# Altium schematic sheet FEZ-Bit-Misc.SchDoc(FEZ-Bit-Misc)
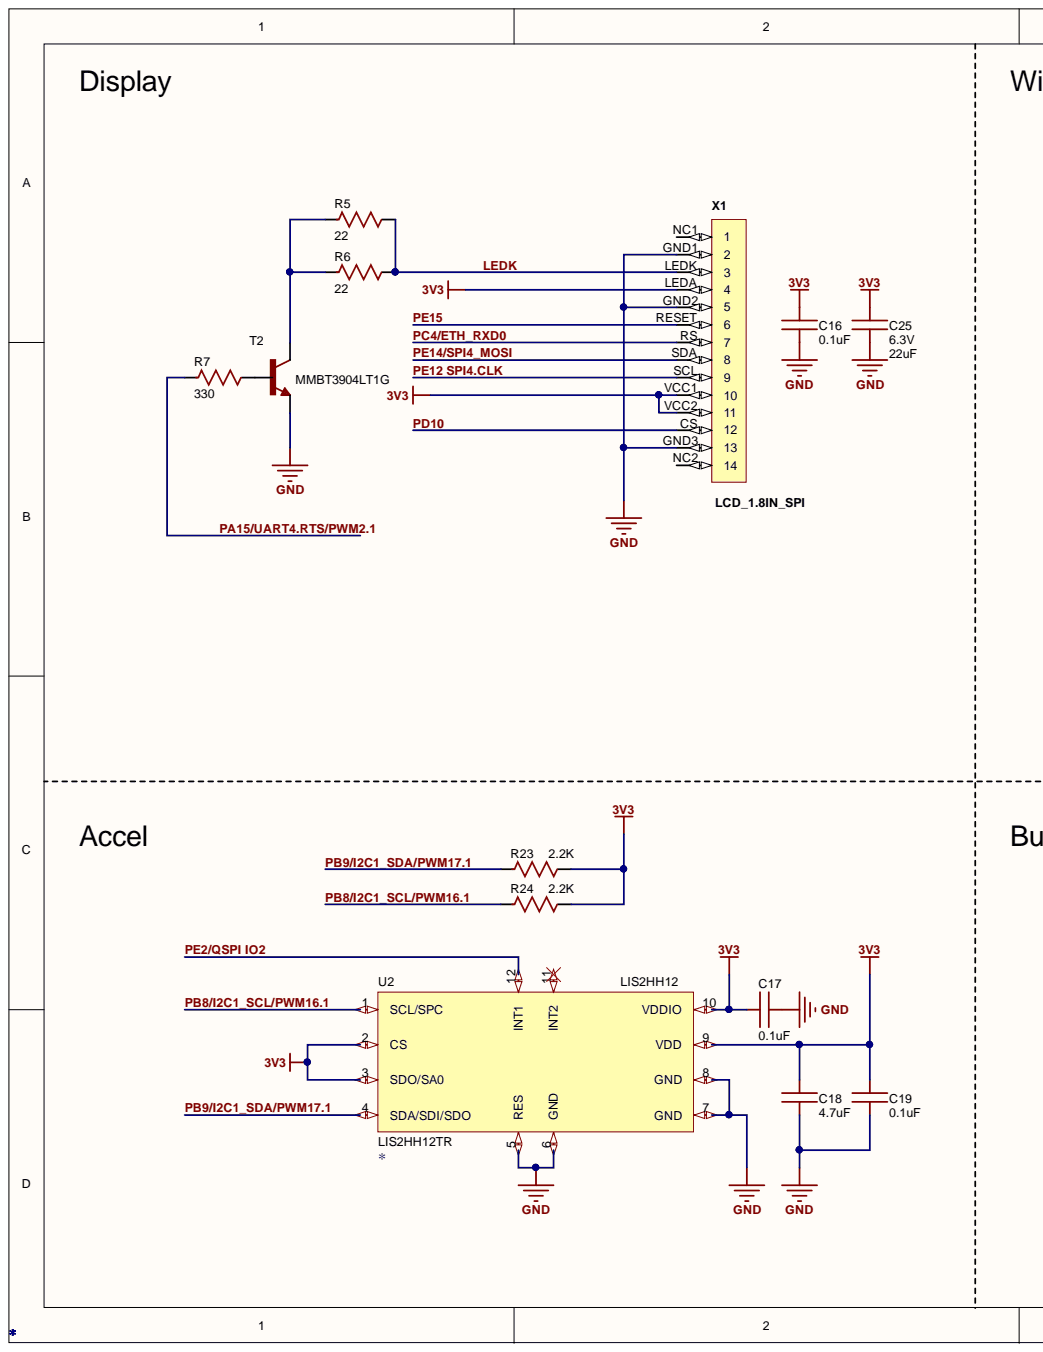
[diagram p0: FEZ-Bit-Misc.SchDoc, left]
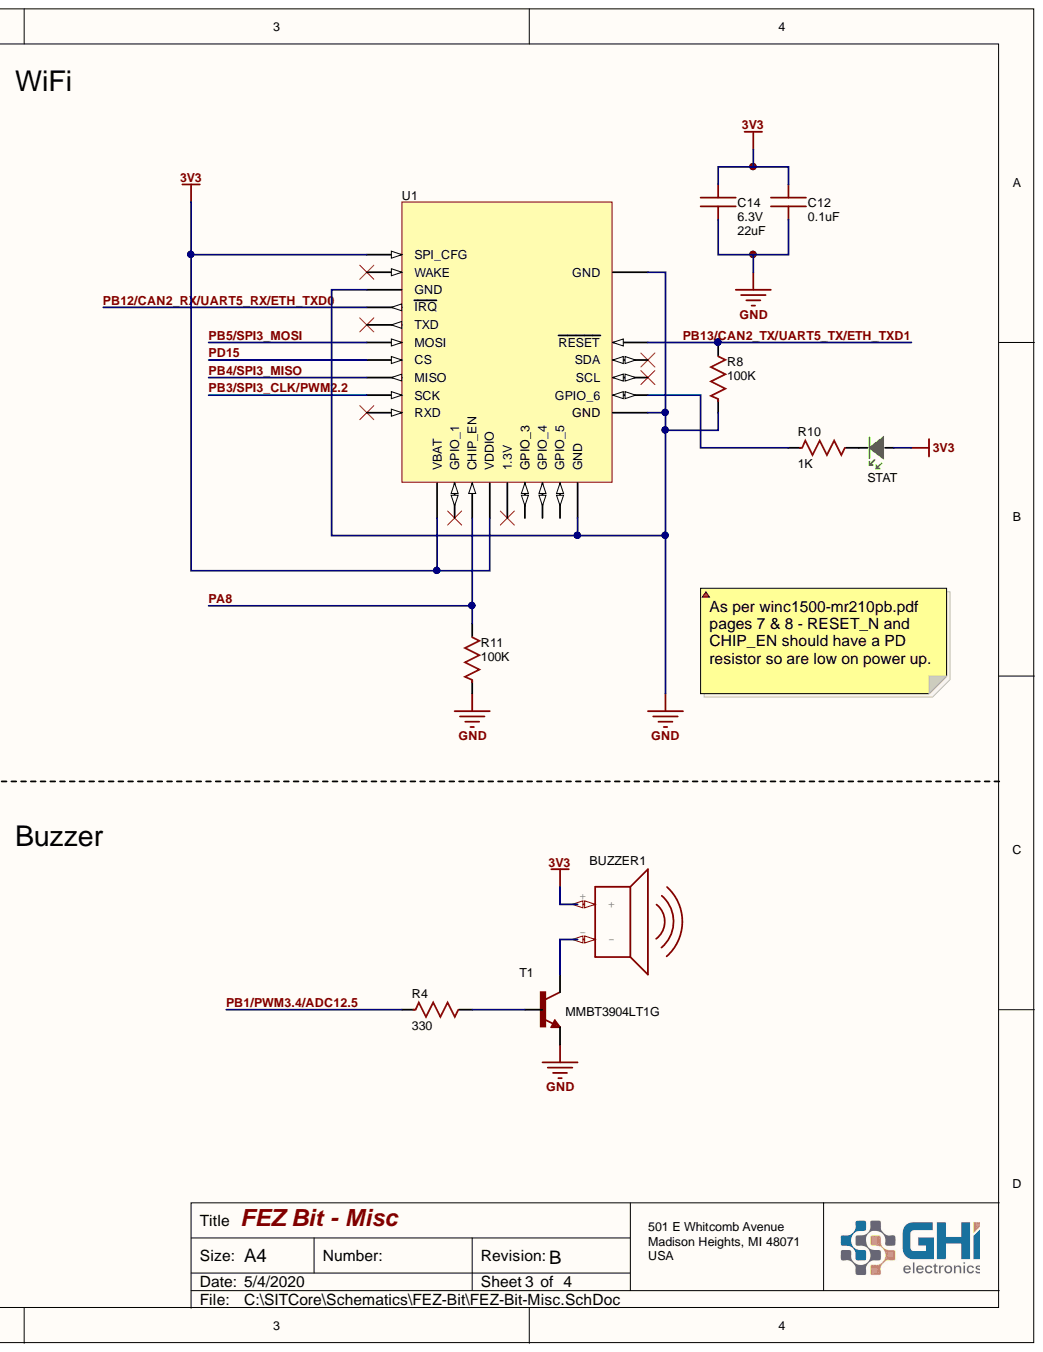
[diagram p1: FEZ-Bit-Misc.SchDoc, right]

## Parts
BUZZER1  Buzzer - Small  [KLJ-SMD-BUZZER]  (value not drawn)
C12  value 0.1uF  [C0603 (1608 Metric)]  (hidden comment: 0603 Cap 0.1uF)
C14  value 22uF  Voltage: 6.3V  [C0805 L]  (hidden comment: 0805 Cap 22uF)
C16  value 0.1uF  [C0603 (1608 Metric)]  (hidden comment: 0603 Cap 0.1uF)
C17  value 0.1uF  [C0603 (1608 Metric)]  (hidden comment: 0603 Cap 0.1uF)
C18  value 4.7uF  [C0603 (1608 Metric)]  (hidden comment: 0603 Cap 4.7uF)
C19  value 0.1uF  [C0603 (1608 Metric)]  (hidden comment: 0603 Cap 0.1uF)
C25  value 22uF  Voltage: 6.3V  [C0805 L]  (hidden comment: 0805 Cap 22uF)
R4  value 330  [R0603 (1608 Metric)]  (hidden comment: 0603 330)
R5  value 22  [R0603 (1608 Metric)]  (hidden comment: 0603 22)
R6  value 22  [R0603 (1608 Metric)]  (hidden comment: 0603 22)
R7  value 330  [R0603 (1608 Metric)]  (hidden comment: 0603 330)
R8  value 100K  [R0603 (1608 Metric)]  (hidden comment: 0603 Res 100K)
R10  value 1K  [R0603 (1608 Metric)]  (hidden comment: 0603 1K)
R11  value 100K  [R0603 (1608 Metric)]  (hidden comment: 0603 Res 100K)
R23  value 2.2K  [R0603 (1608 Metric)]  (hidden comment: 0603 2.2K)
R24  value 2.2K  [R0603 (1608 Metric)]  (hidden comment: 0603 2.2K)
STAT  0603 LED Green  [0603 LED Green]  (value not drawn)
T1  3904  value MMBT3904LT1G  [SOT23]
T2  3904  value MMBT3904LT1G  [SOT23]
U1  ATWINC1500_MR210PB  [ATWINC1500_MR210PA]  (value not drawn)
U2  value LIS2HH12TR  [LGA-12(ST)]
X1  LCD_1.8IN_SPI  [LCD_1.8IN]

## Nets
3V3: BUZZER1.+ C12.2 C14.2 C16.2 C17.2 C18.2 C19.2 C25.2 R23.2 R24.2 STAT.2 U1.J10(SPI_CFG) U1.J20(VBAT) U1.J23(VDDIO) U2.2(CS) U2.3(SDO/SA0) U2.9(VDD) U2.10(VDDIO) X1.LEDA X1.VCC1 X1.VCC2
GND: C12.1 C14.1 C16.1 C17.1 C18.1 C19.1 C25.1 R8.1 R11.1 T1.2 T2.2 U1.J9(GND) U1.J12(GND) U1.J28(GND) U1.J29(GND) U2.5(RES) U2.6(GND) U2.7(GND) U2.8(GND) X1.GND1 X1.GND2 X1.GND3
LEDK: R5.2 R6.2 X1.LEDK
PA8: R11.2 U1.J22(CHIP_EN)
PA15/UART4.RTS/PWM2.1: R7.1
PB1/PWM3.4/ADC12.5: R4.1
PB3/SPI3_CLK/PWM2.2: U1.J18(SCK)
PB4/SPI3_MISO: U1.J17(MISO)
PB5/SPI3_MOSI: U1.J15(MOSI)
PB8/I2C1_SCL/PWM16.1: R24.1 U2.1(SCL/SPC)
PB9/I2C1_SDA/PWM17.1: R23.1 U2.4(SDA/SDI/SDO)
PB12/CAN2_RX/UART5_RX/ETH_TXD0: U1.J13(IRQ)
PB13/CAN2_TX/UART5_TX/ETH_TXD1: R8.2 U1.J4(RESET)
PC4/ETH_RXD0: X1.RS
PD10: X1.CS
PD15: U1.J16(CS)
PE2/QSPI IO2: U2.12(INT1)
PE12 SPI4.CLK: X1.SCL
PE14/SPI4_MOSI: X1.SDA
PE15: X1.RESET
NetBUZZER1_-: BUZZER1.- T1.3
NetR4_2: R4.2 T1.1
NetR5_1: R5.1 R6.1 T2.3
NetR7_2: R7.2 T2.1
NetR10_1: R10.1 U1.J1(GPIO_6)
NetR10_2: R10.2 STAT.1

Not connected: U1 J2(SCL) J3(SDA) J11(WAKE) J14(TXD) J19(RXD) J21(GPIO_1) J24(1.3V) J25(GPIO_3) J26(GPIO_4) J27(GPIO_5); U2 11(INT2); X1 NC1 NC2

## Library data of the parts
BUZZER1 = KLJ-8530-5027: Piezo Speaker from CHANGZHOU KELIKING ELECTRONICS CO., LTD; Value: KLJ-8530-5027; PartNo: KLJ-8530-5027; Component Kind: Standard; Library Name: GHI.SchLib
C12 C16 C17 C19 = 0603 Cap 0.1uF: 0.1uF ±5% 50V X7R 0603 Multilayer Ceramic Capacitors MLCC - SMD/SMT RoHS; Mfr.Part #: CL10B104JB8NNNC; Voltage: 50V; Tolerance: ±5%; Package: 0603; LCSC Part #: C24452; Digikey: 1276-1033-1-ND; Component Kind: Standard; Category: Ceramic; Capacitance: 0.1uF; Library Name: GHI-Passives.SchLib
C14 C25 = 0805 Cap 22uF: 22uF ±20% 6.3V X5R 0805 Multilayer Ceramic Capacitors MLCC - SMD/SMT RoHS; Mfr.Part #: CL21A226MQCLRNC; Tolerance: ±20%; Package: 0805; LCSC Part #: C307530; Component Kind: Standard; Category: Ceramic; Capacitance: 22uF; Library Name: GHI-Passives.SchLib
C18 = 0603 Cap 4.7uF: 4.7uF ±10% 25V X5R 0603 Multilayer Ceramic Capacitors MLCC - SMD/SMT RoHS; Mfr.Part #: CL10A475KA8NQNC; Manufacturer: Samsung Electro-Mechanics; Voltage: 25V; Tolerance: ±10%; Package: 0603; LCSC Part #: C69335; Component Kind: Standard; Category: Ceramic; Capacitance: 4.7uF; Library Name: GHI-Passives.SchLib
R4 R7 = 0603 Res 330: 330 ±5% 1/10W ±100ppm/℃ 0603 Chip Resistor - Surface Mount RoHS; Mfr.Part #: WR06X331 JTL; Manufacturer: Walsin Tech Corp; Voltage Rating: *V; Resistance Tolerance: ±5%; Resistance: 330; Power Rating: 1/10W; Package: 0603; LCSC Part #: C384242; Component Kind: Standard; Library Name: GHI-Passives.SchLib
R5 R6 = 0603 Res 22: 22 ±1% 1/10W ±100ppm/℃ 0603 Chip Resistor - Surface Mount RoHS; Mfr.Part #: RC0603FR-0722RL; Manufacturer: YAGEO; Voltage Rating: *V; Resistance Tolerance: ±1%; Resistance: 22; Power Rating: 1/10W; Package: 0603; LCSC Part #: C107701; Component Kind: Standard; Library Name: GHI-Passives.SchLib
R8 R11 = 0603 Res 100K: 100K ±1% 1/10W ±100ppm/℃ 0603 Chip Resistor - Surface Mount RoHS; Mfr.Part #: RC0603FR-07100KL; Manufacturer: YAGEO; Voltage Rating: *V; Resistance Tolerance: ±1%; Resistance: 100K; Power Rating: 1/10W; Package: 0603; LCSC Part #: C14675; Component Kind: Standard; Library Name: GHI-Passives.SchLib
R10 = 0603 Res 1K: 1K ±5% 1/10W ±100ppm/℃ 0603 Chip Resistor - Surface Mount RoHS; Mfr.Part #: WR06X102 JTL; Manufacturer: Walsin Tech Corp; Voltage Rating: *V; Resistance Tolerance: ±5%; Resistance: 1K; Power Rating: 1/10W; Package: 0603; LCSC Part #: C384295; Component Kind: Standard; Library Name: GHI-Passives.SchLib
R23 R24 = 0603 Res 2.2K: 2.2K ±1% 1/10W ±100ppm/℃ 0603 Chip Resistor - Surface Mount RoHS; Mfr.Part #: RC0603FR-072K2L; Manufacturer: YAGEO; Voltage Rating: *V; Resistance Tolerance: ±1%; Resistance: 2.2K; Power Rating: 1/10W; Package: 0603; LCSC Part #: C114662; Component Kind: Standard; Library Name: GHI-Passives.SchLib
STAT = 0603 LED Green: Green 567.5~576.5nm 0603 Light Emitting Diodes (LED) RoHS; Mfr.Part #: LTST-C193KGKT-5A; Manufacturer: Lite-On; Value: 0603 LED Green; Package: 0603; LCSC Part #: C125102; Component Kind: Standard; Color: Green; Library Name: GHI-Opto.SchLib
T1 T2 = MMBT3904LT1G: NPN 200mA 40V 300mW SOT-23(SOT-23-3) Transistors (NPN/PNP) RoHS; Manufacturer: ON Semicon; Package: SOT-23(SOT-23-3); Mfr.Part #: MMBT3904LT1G; LCSC Part #: C81464; Component Kind: Standard; Library Name: GHI-Semiconductors.SchLib
U1 = ATWINC1500_MR210PA: ATWINC1500_MR210PA wifi module; Value: ATWINC1500-MR210UB1954; PartNo: ATWINC1500-MR210UB1954; Component Kind: Standard; Library Name: GHI.SchLib
U2 = LIS2HH12: ST - MEMS digital output motion sensor: ultra-low-power high-performance 3-axis "pico" accelerometer; Package: LGA12; Component Kind: Standard; : LIS2HH12; Library Name: GHI.SchLib
X1 = LCD_1.8IN_SPI: SymbolName_1: 14_PINS; GateName_1: G$1; DeviceSetName: LCD_1.8IN_SPI; Component Kind: Standard; LibraryName: GHI; Library Name: GHI Sch.SchLib
